# Altium schematic sheet ActiveShield.SchDoc
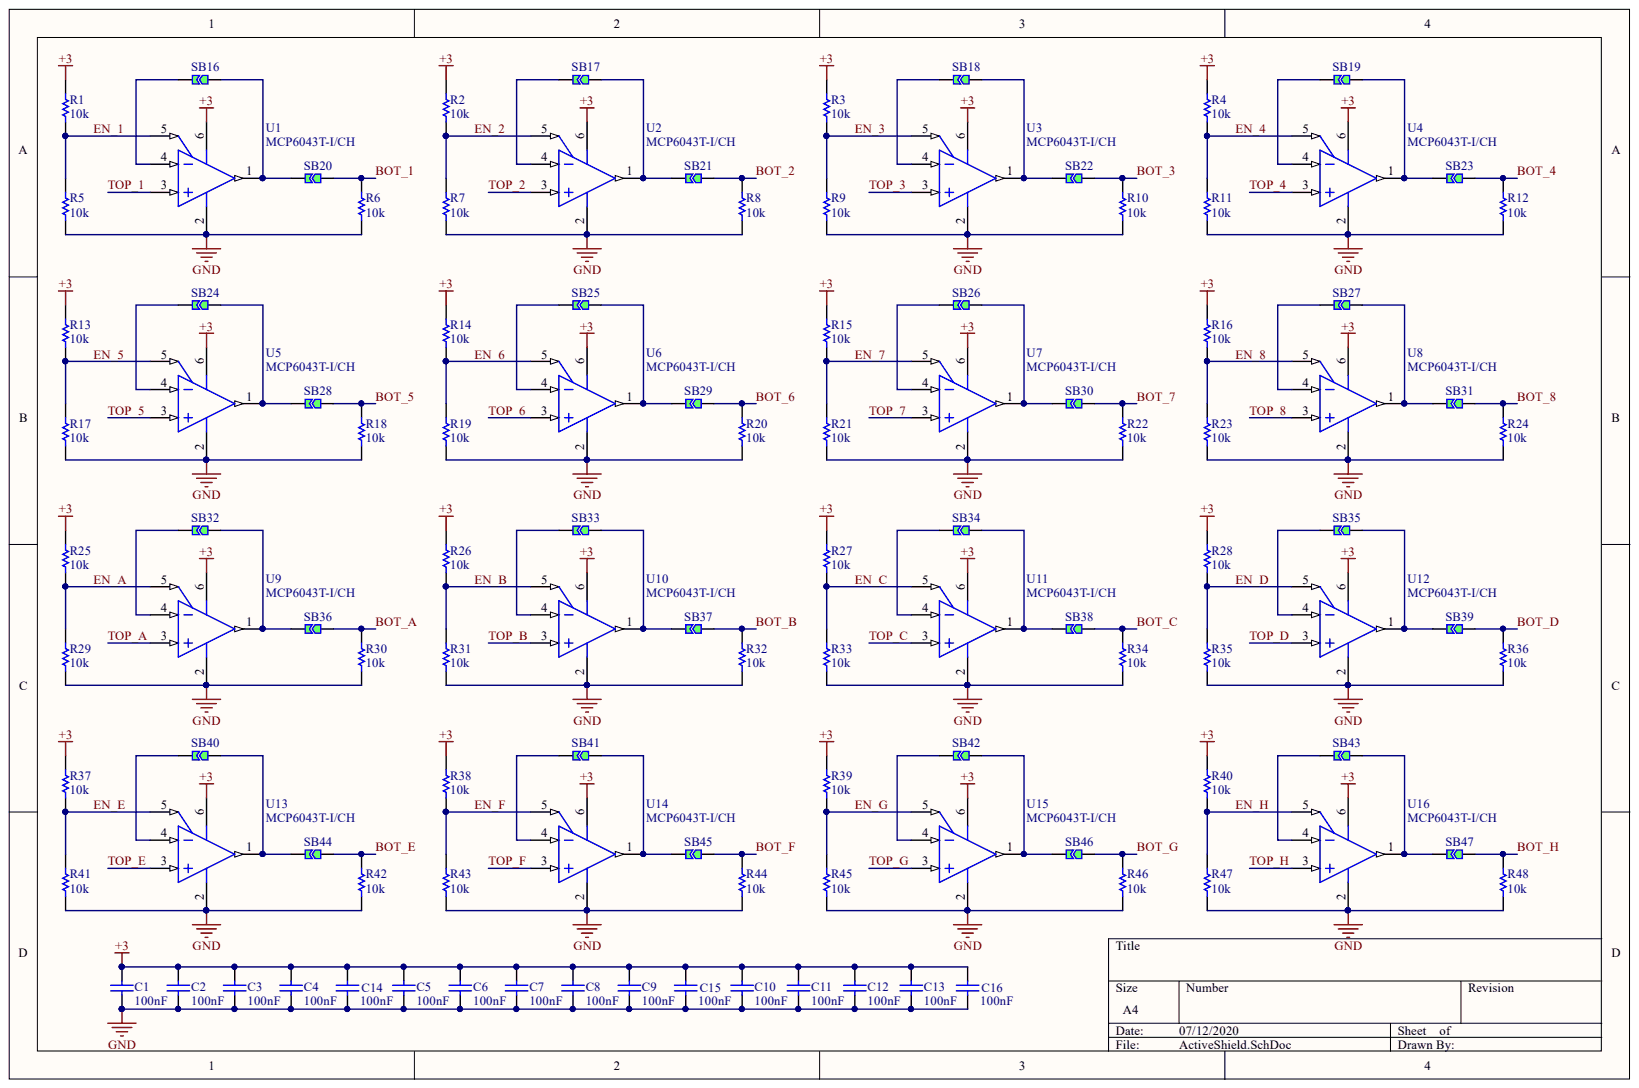
[diagram p0: ActiveShield.SchDoc]

## Parts
C1  100nF  [PCB-srj5nzvxxiuyr0ufdy6u-1]
C2  100nF  [PCB-srj5nzvxxiuyr0ufdy6u-1]
C3  100nF  [PCB-srj5nzvxxiuyr0ufdy6u-1]
C4  100nF  [PCB-srj5nzvxxiuyr0ufdy6u-1]
C5  100nF  [PCB-srj5nzvxxiuyr0ufdy6u-1]
C6  100nF  [PCB-srj5nzvxxiuyr0ufdy6u-1]
C7  100nF  [PCB-srj5nzvxxiuyr0ufdy6u-1]
C8  100nF  [PCB-srj5nzvxxiuyr0ufdy6u-1]
C9  100nF  [PCB-srj5nzvxxiuyr0ufdy6u-1]
C10  100nF  [PCB-srj5nzvxxiuyr0ufdy6u-1]
C11  100nF  [PCB-srj5nzvxxiuyr0ufdy6u-1]
C12  100nF  [PCB-srj5nzvxxiuyr0ufdy6u-1]
C13  100nF  [PCB-srj5nzvxxiuyr0ufdy6u-1]
C14  100nF  [PCB-srj5nzvxxiuyr0ufdy6u-1]
C15  100nF  [PCB-srj5nzvxxiuyr0ufdy6u-1]
C16  100nF  [PCB-srj5nzvxxiuyr0ufdy6u-1]
R1  10k  [RESC1005X04M]
R2  10k  [RESC1005X04M]
R3  10k  [RESC1005X04M]
R4  10k  [RESC1005X04M]
R5  10k  [RESC1005X04M]
R6  10k  [RESC1005X04M]
R7  10k  [RESC1005X04M]
R8  10k  [RESC1005X04M]
R9  10k  [RESC1005X04M]
R10  10k  [RESC1005X04M]
R11  10k  [RESC1005X04M]
R12  10k  [RESC1005X04M]
R13  10k  [RESC1005X04M]
R14  10k  [RESC1005X04M]
R15  10k  [RESC1005X04M]
R16  10k  [RESC1005X04M]
R17  10k  [RESC1005X04M]
R18  10k  [RESC1005X04M]
R19  10k  [RESC1005X04M]
R20  10k  [RESC1005X04M]
R21  10k  [RESC1005X04M]
R22  10k  [RESC1005X04M]
R23  10k  [RESC1005X04M]
R24  10k  [RESC1005X04M]
R25  10k  [RESC1005X04M]
R26  10k  [RESC1005X04M]
R27  10k  [RESC1005X04M]
R28  10k  [RESC1005X04M]
R29  10k  [RESC1005X04M]
R30  10k  [RESC1005X04M]
R31  10k  [RESC1005X04M]
R32  10k  [RESC1005X04M]
R33  10k  [RESC1005X04M]
R34  10k  [RESC1005X04M]
R35  10k  [RESC1005X04M]
R36  10k  [RESC1005X04M]
R37  10k  [RESC1005X04M]
R38  10k  [RESC1005X04M]
R39  10k  [RESC1005X04M]
R40  10k  [RESC1005X04M]
R41  10k  [RESC1005X04M]
R42  10k  [RESC1005X04M]
R43  10k  [RESC1005X04M]
R44  10k  [RESC1005X04M]
R45  10k  [RESC1005X04M]
R46  10k  [RESC1005X04M]
R47  10k  [RESC1005X04M]
R48  10k  [RESC1005X04M]
SB16  Solder Bridge 0402  [PCB-zgo6wgtf9tfvr4qcvek3-1]  (value not drawn)
SB17  Solder Bridge 0402  [PCB-zgo6wgtf9tfvr4qcvek3-1]  (value not drawn)
SB18  Solder Bridge 0402  [PCB-zgo6wgtf9tfvr4qcvek3-1]  (value not drawn)
SB19  Solder Bridge 0402  [PCB-zgo6wgtf9tfvr4qcvek3-1]  (value not drawn)
SB20  Solder Bridge 0402  [PCB-zgo6wgtf9tfvr4qcvek3-1]  (value not drawn)
SB21  Solder Bridge 0402  [PCB-zgo6wgtf9tfvr4qcvek3-1]  (value not drawn)
SB22  Solder Bridge 0402  [PCB-zgo6wgtf9tfvr4qcvek3-1]  (value not drawn)
SB23  Solder Bridge 0402  [PCB-zgo6wgtf9tfvr4qcvek3-1]  (value not drawn)
SB24  Solder Bridge 0402  [PCB-zgo6wgtf9tfvr4qcvek3-1]  (value not drawn)
SB25  Solder Bridge 0402  [PCB-zgo6wgtf9tfvr4qcvek3-1]  (value not drawn)
SB26  Solder Bridge 0402  [PCB-zgo6wgtf9tfvr4qcvek3-1]  (value not drawn)
SB27  Solder Bridge 0402  [PCB-zgo6wgtf9tfvr4qcvek3-1]  (value not drawn)
SB28  Solder Bridge 0402  [PCB-zgo6wgtf9tfvr4qcvek3-1]  (value not drawn)
SB29  Solder Bridge 0402  [PCB-zgo6wgtf9tfvr4qcvek3-1]  (value not drawn)
SB30  Solder Bridge 0402  [PCB-zgo6wgtf9tfvr4qcvek3-1]  (value not drawn)
SB31  Solder Bridge 0402  [PCB-zgo6wgtf9tfvr4qcvek3-1]  (value not drawn)
SB32  Solder Bridge 0402  [PCB-zgo6wgtf9tfvr4qcvek3-1]  (value not drawn)
SB33  Solder Bridge 0402  [PCB-zgo6wgtf9tfvr4qcvek3-1]  (value not drawn)
SB34  Solder Bridge 0402  [PCB-zgo6wgtf9tfvr4qcvek3-1]  (value not drawn)
SB35  Solder Bridge 0402  [PCB-zgo6wgtf9tfvr4qcvek3-1]  (value not drawn)
SB36  Solder Bridge 0402  [PCB-zgo6wgtf9tfvr4qcvek3-1]  (value not drawn)
SB37  Solder Bridge 0402  [PCB-zgo6wgtf9tfvr4qcvek3-1]  (value not drawn)
SB38  Solder Bridge 0402  [PCB-zgo6wgtf9tfvr4qcvek3-1]  (value not drawn)
SB39  Solder Bridge 0402  [PCB-zgo6wgtf9tfvr4qcvek3-1]  (value not drawn)
SB40  Solder Bridge 0402  [PCB-zgo6wgtf9tfvr4qcvek3-1]  (value not drawn)
SB41  Solder Bridge 0402  [PCB-zgo6wgtf9tfvr4qcvek3-1]  (value not drawn)
SB42  Solder Bridge 0402  [PCB-zgo6wgtf9tfvr4qcvek3-1]  (value not drawn)
SB43  Solder Bridge 0402  [PCB-zgo6wgtf9tfvr4qcvek3-1]  (value not drawn)
SB44  Solder Bridge 0402  [PCB-zgo6wgtf9tfvr4qcvek3-1]  (value not drawn)
SB45  Solder Bridge 0402  [PCB-zgo6wgtf9tfvr4qcvek3-1]  (value not drawn)
SB46  Solder Bridge 0402  [PCB-zgo6wgtf9tfvr4qcvek3-1]  (value not drawn)
SB47  Solder Bridge 0402  [PCB-zgo6wgtf9tfvr4qcvek3-1]  (value not drawn)
U1  MCP6043T-I/CH  [SOT-23-CH6_N]
U2  MCP6043T-I/CH  [SOT-23-CH6_N]
U3  MCP6043T-I/CH  [SOT-23-CH6_N]
U4  MCP6043T-I/CH  [SOT-23-CH6_N]
U5  MCP6043T-I/CH  [SOT-23-CH6_N]
U6  MCP6043T-I/CH  [SOT-23-CH6_N]
U7  MCP6043T-I/CH  [SOT-23-CH6_N]
U8  MCP6043T-I/CH  [SOT-23-CH6_N]
U9  MCP6043T-I/CH  [SOT-23-CH6_N]
U10  MCP6043T-I/CH  [SOT-23-CH6_N]
U11  MCP6043T-I/CH  [SOT-23-CH6_N]
U12  MCP6043T-I/CH  [SOT-23-CH6_N]
U13  MCP6043T-I/CH  [SOT-23-CH6_N]
U14  MCP6043T-I/CH  [SOT-23-CH6_N]
U15  MCP6043T-I/CH  [SOT-23-CH6_N]
U16  MCP6043T-I/CH  [SOT-23-CH6_N]

## Nets
+3: C1.2 C2.2 C3.2 C4.2 C5.2 C6.2 C7.2 C8.2 C9.2 C10.2 C11.2 C12.2 C13.2 C14.2 C15.2 C16.2 R1.1 R2.1 R3.1 R4.1 R13.1 R14.1 R15.1 R16.1 R25.1 R26.1 R27.1 R28.1 R37.1 R38.1 R39.1 R40.1 U1.6 U2.6 U3.6 U4.6 U5.6 U6.6 U7.6 U8.6 U9.6 U10.6 U11.6 U12.6 U13.6 U14.6 U15.6 U16.6
GND: C1.1 C2.1 C3.1 C4.1 C5.1 C6.1 C7.1 C8.1 C9.1 C10.1 C11.1 C12.1 C13.1 C14.1 C15.1 C16.1 R5.1 R6.1 R7.1 R8.1 R9.1 R10.1 R11.1 R12.1 R17.1 R18.1 R19.1 R20.1 R21.1 R22.1 R23.1 R24.1 R29.1 R30.1 R31.1 R32.1 R33.1 R34.1 R35.1 R36.1 R41.1 R42.1 R43.1 R44.1 R45.1 R46.1 R47.1 R48.1 U1.2 U2.2 U3.2 U4.2 U5.2 U6.2 U7.2 U8.2 U9.2 U10.2 U11.2 U12.2 U13.2 U14.2 U15.2 U16.2
BOT_1: R6.2 SB20.2
BOT_2: R8.2 SB21.2
BOT_3: R10.2 SB22.2
BOT_4: R12.2 SB23.2
BOT_5: R18.2 SB28.2
BOT_6: R20.2 SB29.2
BOT_7: R22.2 SB30.2
BOT_8: R24.2 SB31.2
BOT_A: R30.2 SB36.2
BOT_B: R32.2 SB37.2
BOT_C: R34.2 SB38.2
BOT_D: R36.2 SB39.2
BOT_E: R42.2 SB44.2
BOT_F: R44.2 SB45.2
BOT_G: R46.2 SB46.2
BOT_H: R48.2 SB47.2
EN_1: R1.2 R5.2 U1.5
EN_2: R2.2 R7.2 U2.5
EN_3: R3.2 R9.2 U3.5
EN_4: R4.2 R11.2 U4.5
EN_5: R13.2 R17.2 U5.5
EN_6: R14.2 R19.2 U6.5
EN_7: R15.2 R21.2 U7.5
EN_8: R16.2 R23.2 U8.5
EN_A: R25.2 R29.2 U9.5
EN_B: R26.2 R31.2 U10.5
EN_C: R27.2 R33.2 U11.5
EN_D: R28.2 R35.2 U12.5
EN_E: R37.2 R41.2 U13.5
EN_F: R38.2 R43.2 U14.5
EN_G: R39.2 R45.2 U15.5
EN_H: R40.2 R47.2 U16.5
TOP_1: U1.3
TOP_2: U2.3
TOP_3: U3.3
TOP_4: U4.3
TOP_5: U5.3
TOP_6: U6.3
TOP_7: U7.3
TOP_8: U8.3
TOP_A: U9.3
TOP_B: U10.3
TOP_C: U11.3
TOP_D: U12.3
TOP_E: U13.3
TOP_F: U14.3
TOP_G: U15.3
TOP_H: U16.3
NetSB16_1: SB16.1 U1.4
NetSB16_2: SB16.2 SB20.1 U1.1
NetSB17_1: SB17.1 U2.4
NetSB17_2: SB17.2 SB21.1 U2.1
NetSB18_1: SB18.1 U3.4
NetSB18_2: SB18.2 SB22.1 U3.1
NetSB19_1: SB19.1 U4.4
NetSB19_2: SB19.2 SB23.1 U4.1
NetSB24_1: SB24.1 U5.4
NetSB24_2: SB24.2 SB28.1 U5.1
NetSB25_1: SB25.1 U6.4
NetSB25_2: SB25.2 SB29.1 U6.1
NetSB26_1: SB26.1 U7.4
NetSB26_2: SB26.2 SB30.1 U7.1
NetSB27_1: SB27.1 U8.4
NetSB27_2: SB27.2 SB31.1 U8.1
NetSB32_1: SB32.1 U9.4
NetSB32_2: SB32.2 SB36.1 U9.1
NetSB33_1: SB33.1 U10.4
NetSB33_2: SB33.2 SB37.1 U10.1
NetSB34_1: SB34.1 U11.4
NetSB34_2: SB34.2 SB38.1 U11.1
NetSB35_1: SB35.1 U12.4
NetSB35_2: SB35.2 SB39.1 U12.1
NetSB40_1: SB40.1 U13.4
NetSB40_2: SB40.2 SB44.1 U13.1
NetSB41_1: SB41.1 U14.4
NetSB41_2: SB41.2 SB45.1 U14.1
NetSB42_1: SB42.1 U15.4
NetSB42_2: SB42.2 SB46.1 U15.1
NetSB43_1: SB43.1 U16.4
NetSB43_2: SB43.2 SB47.1 U16.1

## Library data of the parts
C1-C16 = CMP-16527024-7: 0402 100nF 6.3V X5R; Capacitance Tolerance: ±10%; Lead-Free Status: Lead Free; Voltage Rating (DC): 16.0 V; Capacitance: 100 nF; Case/Package: 0402; Component Kind: Standard; Dielectric Characteristic: X5R; Library Name: CommunityVault; Mounting Style: Surface Mount; Number of Pins: 2; OctopartID: 6877a17fb5d5b7b0; Operating Temperature: -55.0 °C to 85.0 °C; Packaging: Cut Tape (CT), Reel, Tape & Reel (TR); REACH SVHC Compliance: No SVHC; RoHS: Compliant; Size-Height: 560 µm; Size-Length: 1.00 mm; Size-Width: 500 µm
R1-R48 = CMP-v99hy84itq0asdedzg70-1: 0402 10k 5%; Body Height: 0.35mm; Body Length: 1mm; Body Width: 0.5mm; Package (Imperial): 0402; Package (Metric): 01005; Resistance: 10K?; Tolerance: 1%; Component Kind: Standard; CustomComponentID: v99hy84itq0asdedzg70; Library Name: CommunityVault
SB16-SB47 = CMP-p5m5ndkvhoystooqawk9-5: Solder Bridge Jumper, can be fitted with 0402 0R resistor for automated production; State: Open / Closed; Component Kind: Standard; CustomComponentID: p5m5ndkvhoystooqawk9; Library Name: FavoritesLibrary
U1-U16 = CMP-1324945-2: 600 nA, Rail-to-Rail Input/Output Operational Amplifier, 6-Pin SOT-23, Industrial Temperature, Tape and Reel; Gain Bandwidth Product: 14000.0 Hz; Input Offset Drift: 1.5e-06 V/K; Lead-Free Status: Lead Free; Number of Channels: 1; Slew Rate: 2.3 V/μs; Case/Package: SOT-23; Component Kind: Standard; Library Name: CommunityVault; Lifecycle Status: Active; Manufacturer Part Number: MCP6043T-I/CH; Mounting Style: Surface Mount; Number of Circuits: 1; Number of Pins: 6; OctopartID: 251eec1265edfad9; Octopart Url: https://octopart.com/mcp6043t-i%2Fch-microchip-419221; Packaging: Tape; RoHS: Compliant
